# Altium schematic sheet pca70182.SchDoc
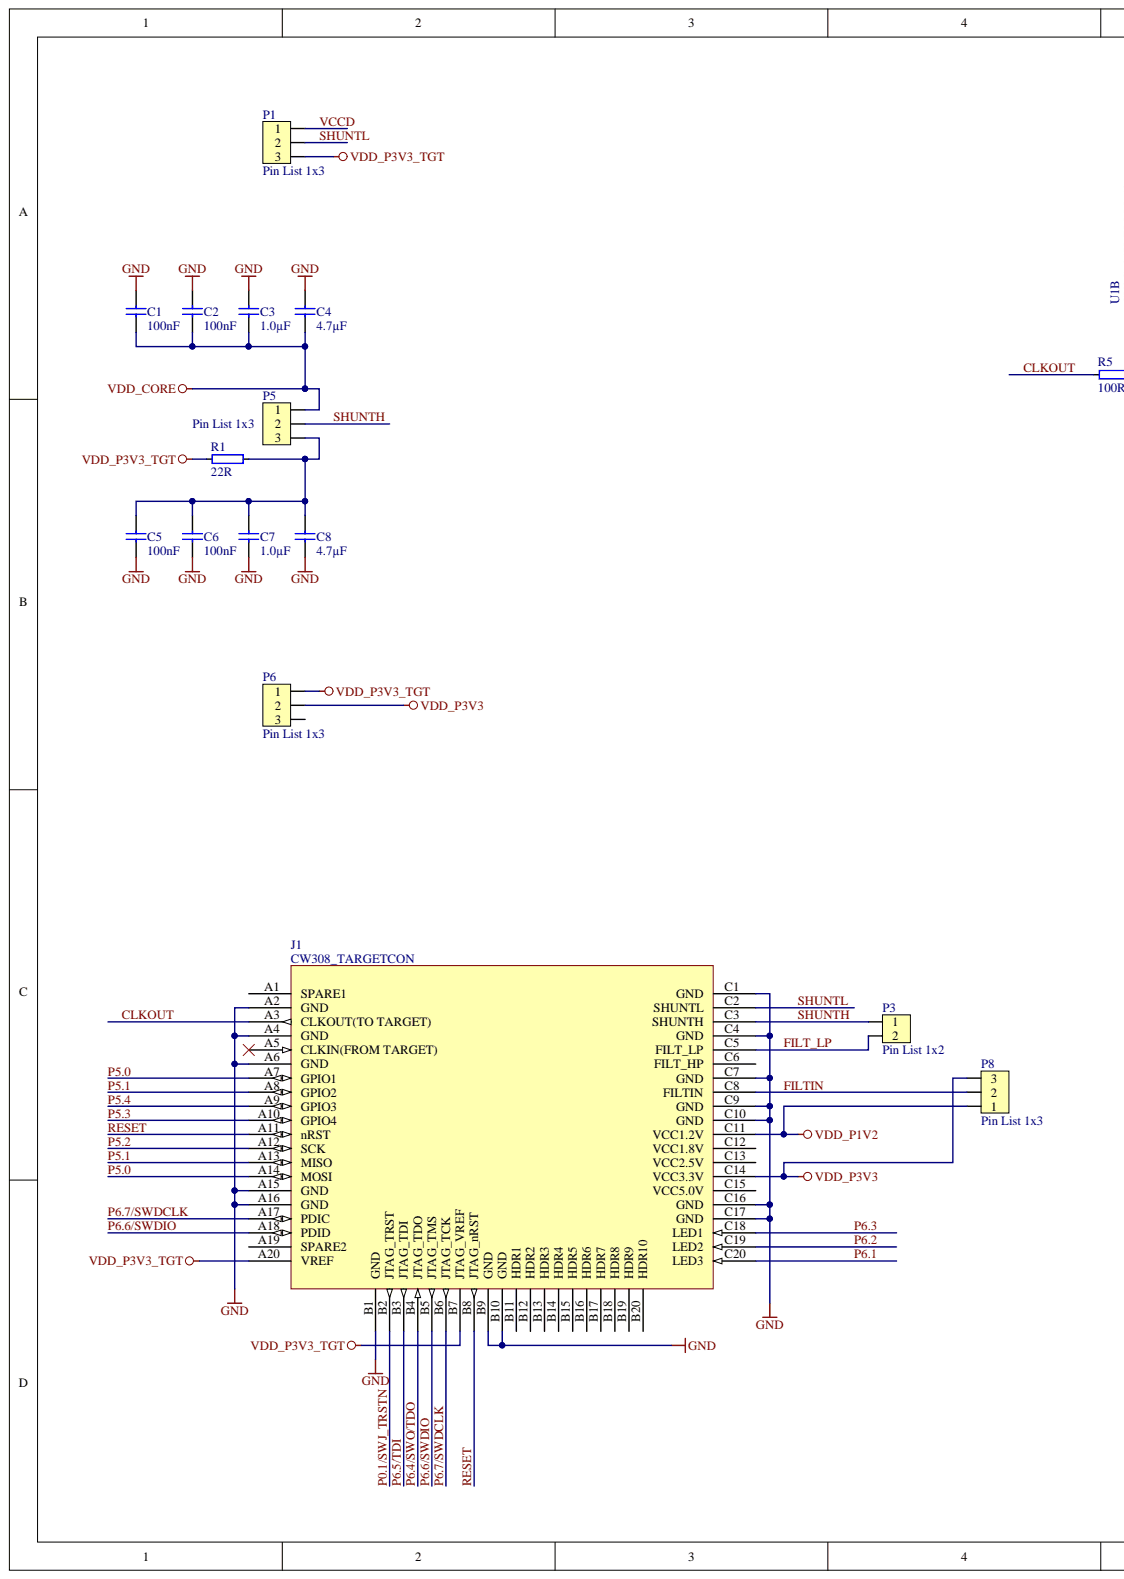
[diagram p0: pca70182.SchDoc, left]
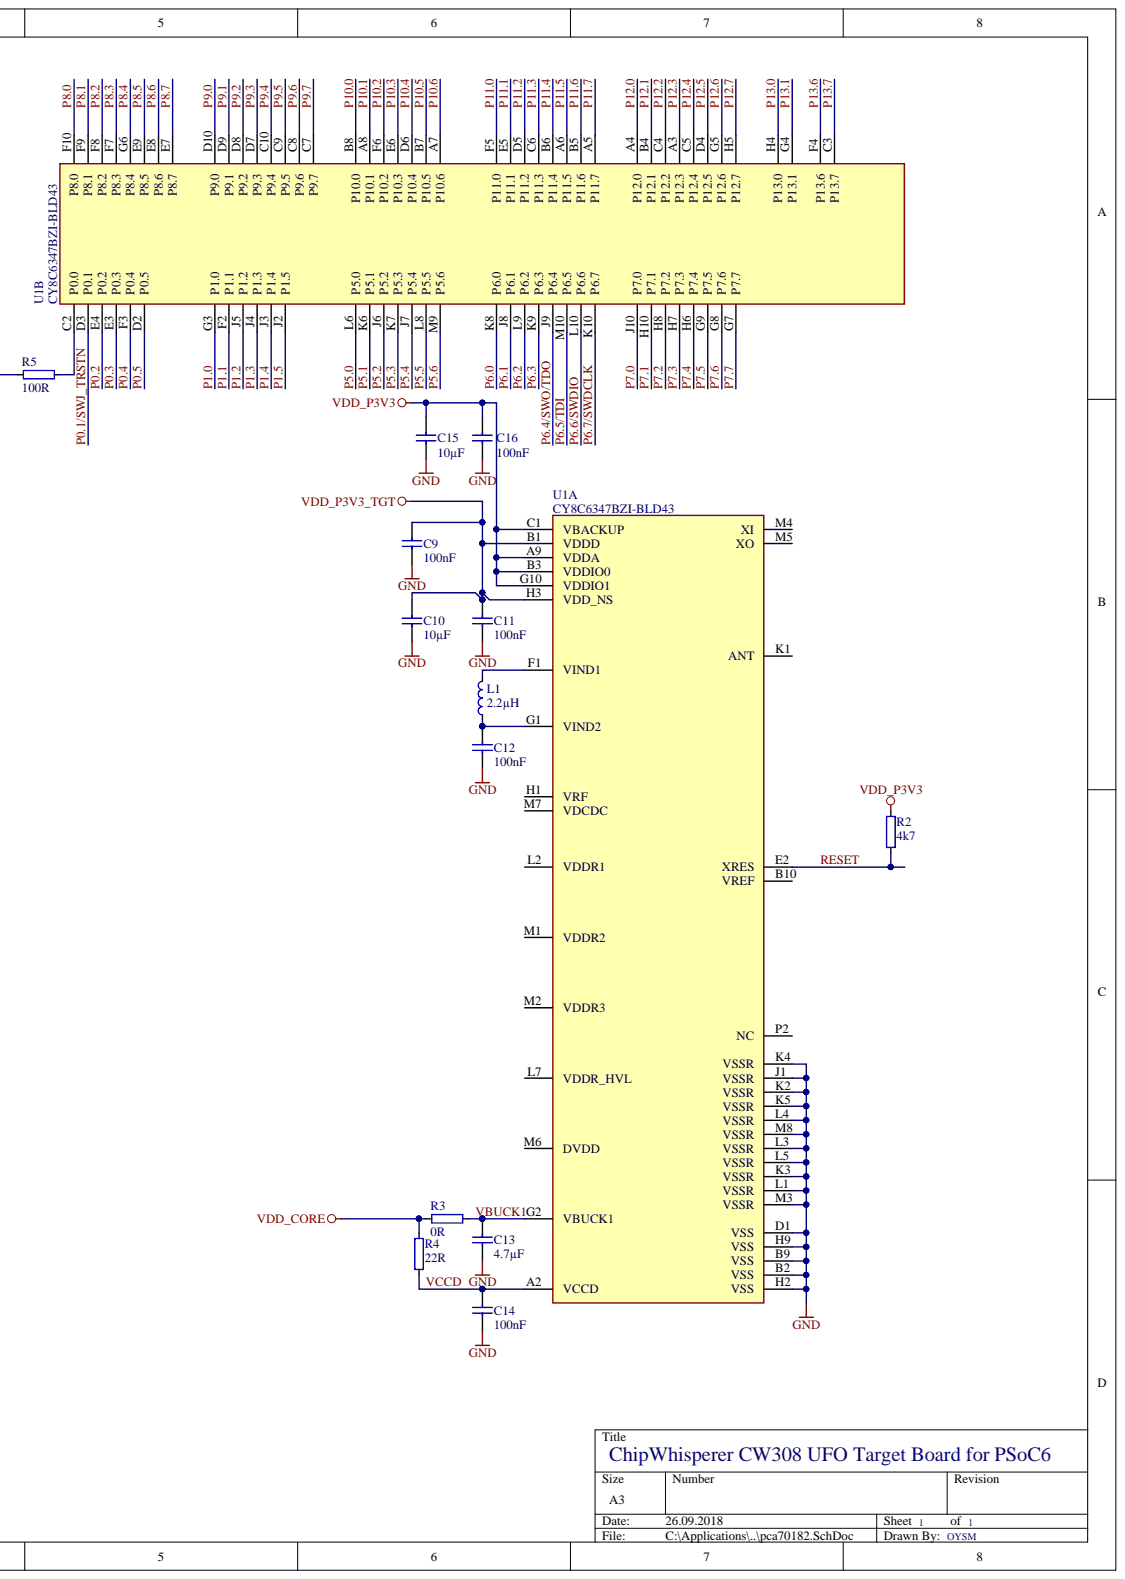
[diagram p1: pca70182.SchDoc, right]

## Parts
C1  100nF  [CAPC1608X06L]
C2  100nF  [CAPC1608X06L]
C3  1.0µF  [CAPC1608X06L]
C4  4.7µF  [CAPC1608X06L]
C5  100nF  [CAPC1608X06L]
C6  100nF  [CAPC1608X06L]
C7  1.0µF  [CAPC1608X06L]
C8  4.7µF  [CAPC1608X06L]
C9  100nF  [CAPC1608X06L]
C10  10µF  [CAPC1608X06L]
C11  100nF  [CAPC1608X06L]
C12  100nF  [CAPC1608X06L]
C13  4.7µF  [CAPC1608X06L]
C14  100nF  [CAPC1608X06L]
C15  10µF  [CAPC1608X06L]
C16  100nF  [CAPC1608X06L]
J1  CW308_TARGETCON  [CW308_TBOARD_CONN]
L1  2.2µH  [INDC1608X06L]
P1  Pin List 1x3  [LIST_1x3]
P3  Pin List 1x2  [LIST_1x2]
P5  Pin List 1x3  [LIST_1x3]
P6  Pin List 1x3  [LIST_1x3]
P8  Pin List 1x3  [LIST_1x3]
R1  22R  [RESC1608X06L]
R2  4k7  [RESC1608X06L]
R3  0R  [RESC1608X06L]
R4  22R  [RESC1608X06L]
R5  100R  [RESC1608X06L]
U1  CY8C6347BZI-BLD43  [BGA116C50P10X12_520X640X70]  (parts A,B)

## Nets
GND: C1.1 C2.1 C3.1 C4.1 C5.1 C6.1 C7.1 C8.1 C9.1 C10.1 C11.1 C12.1 C13.1 C14.1 C15.1 C16.1 J1.A2(GND) J1.A4(GND) J1.A6(GND) J1.A15(GND) J1.A16(GND) J1.B1(GND) J1.B9(GND) J1.B10(GND) J1.C1(GND) J1.C4(GND) J1.C7(GND) J1.C9(GND) J1.C10(GND) J1.C16(GND) J1.C17(GND) U1.B2(VSS) U1.B9(VSS) U1.D1(VSS) U1.H2(VSS) U1.H9(VSS) U1.J1(VSSR) U1.K2(VSSR) U1.K3(VSSR) U1.K4(VSSR) U1.K5(VSSR) U1.L1(VSSR) U1.L3(VSSR) U1.L4(VSSR) U1.L5(VSSR) U1.M3(VSSR) U1.M8(VSSR)
VDD_CORE: C1.2 C2.2 C3.2 C4.2 P5.1 R3.1 R4.2
VDD_P1V2: J1.C11(VCC1.2V) P8.1
VDD_P3V3: C15.2 C16.2 J1.C14(VCC3.3V) P6.2 P8.3 R2.2 U1.A9(VDDA) U1.B3(VDDIO0) U1.C1(VBACKUP) U1.G10(VDDIO1)
VDD_P3V3_TGT: C9.2 C10.2 C11.2 J1.A20(VREF) J1.B7(JTAG_VREF) P1.3 P6.1 R1.1 U1.B1(VDDD) U1.H3(VDD_NS)
CLKOUT: J1.A3(CLKOUT(TO_TARGET)) R5.1
FILT_LP: J1.C5(FILT_LP) P3.2
FILTIN: J1.C8(FILTIN) P8.2
P0.1/SWJ_TRSTN: J1.B2(JTAG_TRST) U1.D3(P0.1)
P0.2: U1.E4(P0.2)
P0.3: U1.E3(P0.3)
P0.4: U1.F3(P0.4)
P0.5: U1.D2(P0.5)
P1.0: U1.G3(P1.0)
P1.1: U1.F2(P1.1)
P1.2: U1.J5(P1.2)
P1.3: U1.J4(P1.3)
P1.4: U1.J3(P1.4)
P1.5: U1.J2(P1.5)
P5.0: J1.A7(GPIO1) J1.A14(MOSI) U1.L6(P5.0)
P5.1: J1.A8(GPIO2) J1.A13(MISO) U1.K6(P5.1)
P5.2: J1.A12(SCK) U1.J6(P5.2)
P5.3: J1.A10(GPIO4) U1.K7(P5.3)
P5.4: J1.A9(GPIO3) U1.J7(P5.4)
P5.5: U1.L8(P5.5)
P5.6: U1.M9(P5.6)
P6.0: U1.K8(P6.0)
P6.1: J1.C20(LED3) U1.J8(P6.1)
P6.2: J1.C19(LED2) U1.L9(P6.2)
P6.3: J1.C18(LED1) U1.K9(P6.3)
P6.4/SWO/TDO: J1.B4(JTAG_TDO) U1.J9(P6.4)
P6.5/TDI: J1.B3(JTAG_TDI) U1.M10(P6.5)
P6.6/SWDIO: J1.A18(PDID) J1.B5(JTAG_TMS) U1.L10(P6.6)
P6.7/SWDCLK: J1.A17(PDIC) J1.B6(JTAG_TCK) U1.K10(P6.7)
P7.0: U1.J10(P7.0)
P7.1: U1.H10(P7.1)
P7.2: U1.H8(P7.2)
P7.3: U1.H7(P7.3)
P7.4: U1.H6(P7.4)
P7.5: U1.G9(P7.5)
P7.6: U1.G8(P7.6)
P7.7: U1.G7(P7.7)
P8.0: U1.F10(P8.0)
P8.1: U1.F9(P8.1)
P8.2: U1.F8(P8.2)
P8.3: U1.F7(P8.3)
P8.4: U1.G6(P8.4)
P8.5: U1.E9(P8.5)
P8.6: U1.E8(P8.6)
P8.7: U1.E7(P8.7)
P9.0: U1.D10(P9.0)
P9.1: U1.D9(P9.1)
P9.2: U1.D8(P9.2)
P9.3: U1.D7(P9.3)
P9.4: U1.C10(P9.4)
P9.5: U1.C9(P9.5)
P9.6: U1.C8(P9.6)
P9.7: U1.C7(P9.7)
P10.0: U1.B8(P10.0)
P10.1: U1.A8(P10.1)
P10.2: U1.F6(P10.2)
P10.3: U1.E6(P10.3)
P10.4: U1.D6(P10.4)
P10.5: U1.B7(P10.5)
P10.6: U1.A7(P10.6)
P11.0: U1.F5(P11.0)
P11.1: U1.E5(P11.1)
P11.2: U1.D5(P11.2)
P11.3: U1.C6(P11.3)
P11.4: U1.B6(P11.4)
P11.5: U1.A6(P11.5)
P11.6: U1.B5(P11.6)
P11.7: U1.A5(P11.7)
P12.0: U1.A4(P12.0)
P12.1: U1.B4(P12.1)
P12.2: U1.C4(P12.2)
P12.3: U1.A3(P12.3)
P12.4: U1.C5(P12.4)
P12.5: U1.D4(P12.5)
P12.6: U1.G5(P12.6)
P12.7: U1.H5(P12.7)
P13.0: U1.H4(P13.0)
P13.1: U1.G4(P13.1)
P13.6: U1.F4(P13.6)
P13.7: U1.C3(P13.7)
RESET: J1.A11(nRST) J1.B8(JTAG_nRST) R2.1 U1.E2(XRES)
SHUNTH: J1.C3(SHUNTH) P3.1 P5.2
SHUNTL: J1.C2(SHUNTL) P1.2
VBUCK1: C13.2 R3.2 U1.G2(VBUCK1)
VCCD: C14.2 P1.1 R4.1 U1.A2(VCCD)
NetC5_2: C5.2 C6.2 C7.2 C8.2 P5.3 R1.2
NetC12_2: C12.2 L1.1 U1.G1(VIND2)
NetL1_2: L1.2 U1.F1(VIND1)
NetR5_2: R5.2 U1.C2(P0.0)

Not connected: J1 A1(SPARE1) A5(CLKIN(FROM_TARGET)) A19(SPARE2) B11(HDR1) B12(HDR2) B13(HDR3) B14(HDR4) B15(HDR5) B16(HDR6) B17(HDR7) B18(HDR8) B19(HDR9) B20(HDR10) C6(FILT_HP) C12(VCC1.8V) C13(VCC2.5V) C15(VCC5.0V); P6 3; U1 B10(VREF) H1(VRF) K1(ANT) L2(VDDR1) L7(VDDR_HVL) M1(VDDR2) M2(VDDR3) M4(XI) M5(XO) M6(DVDD) M7(VDCDC) P2(NC)

## Library data of the parts
C1 C2 C5 C6 C9 C11 C12 C14 C16 = C0603-100n: Chip Cap 0603; Artikkelnr Noca: N.A.; Database Table Name: Capacitors$; Note: Chip Cap 1%; Artikkelnr Simpro: N.A.; Component Kind: Standard; Footprint Doc: 0603; LatestRevisionDate: 2009-05-08; Library Name: Nordic Passive DB.DbLib; Part Number: C0603-100n; Pin Count: 2; Published: 2009-05-08; Value: 100nF; Publisher: Nordic Semiconductor
  C9 C11 C12 C14 C16: ComponentLink1Description: Datasheet; ComponentLink2Description: Supplier link
C3 C7 = C0603-1µ0: Capacitor, X7R, ±10%; Artikkelnr Noca: 20039335; Artikkelnr Simpro: N.A.; Database Table Name: Capacitors$; Note: 16V; Component Kind: Standard; Footprint Doc: 0603; LatestRevisionDate: 2013-06-05; Library Name: Nordic Passive DB.DbLib; Part Number: C0603-1µ0; Pin Count: 2; Published: 2009-05-08; Value: 1.0µF; Publisher: Nordic Semiconductor
C4 C8 = C0603-4µ7: Capacitor, X5R, ±10%; Artikkelnr Noca: 20069060; Artikkelnr Simpro: N.A.; Database Table Name: Capacitors$; Note: 6.3V; Component Kind: Standard; Footprint Doc: 0603; LatestRevisionDate: 2009-11-23; Library Name: Nordic Passive DB.DbLib; Part Number: C0603-4µ7; Pin Count: 2; Published: 2009-05-08; Value: 4.7µF; Publisher: Nordic Semiconductor
C10 C15 = C0603-10µ: Capacitor, X5R, ±20%; Part number IPS: 00000007785; Artikkelnr Noca: 20039234; Artikkelnr Simpro: N.A.; Database Table Name: Capacitors$; Note: 6.3V; Component Kind: Standard; ComponentLink1Description: Datasheet; ComponentLink2Description: Supplier link; Footprint Doc: 0603; LatestRevisionDate: 2011-01-17; Library Name: Nordic Passive DB.DbLib; Part Number: C0603-10µ; Pin Count: 2; Published: 2011-01-17; Value: 10µF; Publisher: Nordic Semiconductor
C13 = C0603-4µ7: Capacitor, X5R, ±10%; Part number IPS: 00000007783; Artikkelnr Noca: 20069060; Artikkelnr Simpro: N.A.; Database Table Name: Capacitors$; Note: 6.3V; Component Kind: Standard; ComponentLink1Description: Datasheet; ComponentLink2Description: Supplier link; Footprint Doc: 0603; LatestRevisionDate: 2009-11-23; Library Name: Nordic Passive DB.DbLib; Part Number: C0603-4µ7; Pin Count: 2; Published: 2009-05-08; Value: 4.7µF; Publisher: Nordic Semiconductor
J1 = CW308_TBOARD: CW308 "Standard" Target Board Connector; Component Kind: Standard; Library Name: ChipWhisperer_AltiumLib.SchLib
L1 = L0603-2.2µH: FIXED IND 2.2UH 700MA 300 MOHM; Manufacturer: Murata; Manufacturer Part Number: LQM18PN2R2NC0; Artikkelnr Noca: N.A.; Database Table Name: Inductors$; Note: -55°C ~ 125°C; Artikkelnr Simpro: N.A.; Component Kind: Standard; ComponentLink1Description: Datasheet; ComponentLink2Description: Supplier link; Footprint Doc: 0603; HelpURL: http://www.murata.com/~/media/webrenewal/support/library/catalog/products/inductor/chip/o05e.ashx; LatestRevisionDate: 2016-04-19; Library Name: Nordic Passive DB.DbLib; Part Number: L0603-2.2µH; Pin Count: 2; Published: 2016-04-19; Value: 2.2µH; Publisher: Nordic Semiconductor
P1 P5 P6 P8 = Pin List 1x3: Pin List 1x3, 2.54mm (100mil); Artikkelnr Noca: 56019041; Artikkelnr Simpro: N.A.; Database Table Name: Connectors$; Component Kind: Standard; Footprint Doc: LIST_1x3; LatestRevisionDate: 2009-12-12; Library Name: Nordic Passive DB.DbLib; Part Number: Pin List 1x3; Pin Count: 3; Published: 2009-12-12; Value: Pin List 1x3; Publisher: Nordic Semiconductor
P3 = Pin List 1x2: Pin List 1x2, 2.54mm (100mil); Artikkelnr Noca: 56019040; Artikkelnr Simpro: N.A.; Database Table Name: Connectors$; Component Kind: Standard; Footprint Doc: LIST_1x2; LatestRevisionDate: 2009-12-12; Library Name: Nordic Passive DB.DbLib; Part Number: Pin List 1x2; Pin Count: 2; Published: 2009-12-12; Value: Pin List 1x2; Publisher: Nordic Semiconductor
R1 R4 = R0603-22R: Resistor, ±1%, 0.1W; Artikkelnr Noca: 27069033; Artikkelnr Simpro: N.A.; Database Table Name: Resistors$; Note: Chip Res 1%; Value Ohm: 22; Component Kind: Standard; Footprint Doc: 0603; LatestRevisionDate: 2011-08-16; Library Name: Nordic Passive DB.DbLib; Part Number: R0603-22R; Pin Count: 2; Published: 2009-05-08; Value: 22R; Publisher: Nordic Semiconductor
R2 = R0603-4k7: Resistor, ±1%, 0.06W; Artikkelnr Noca: 27069089; Artikkelnr Simpro: N.A.; Database Table Name: Resistors$; Note: Chip Res 1%; Value Ohm: 43285000; Component Kind: Standard; ComponentLink1Description: Datasheet; ComponentLink2Description: Supplier link; Footprint Doc: 0603; LatestRevisionDate: 2011-08-16; Library Name: Nordic Passive DB.DbLib; Part Number: R0603-4k7; Pin Count: 2; Published: 2009-05-08; Value: 4k7; Publisher: Nordic Semiconductor
R3 = R0603-0R: Resistor, ±5%, 0.1W; Artikkelnr Noca: 27069000; Artikkelnr Simpro: N.A.; Database Table Name: Resistors$; Note: Chip Res 5%; Value Ohm: #VALUE!; Component Kind: Standard; ComponentLink1Description: Datasheet; ComponentLink2Description: Supplier link; Footprint Doc: 0603; LatestRevisionDate: 2011-12-14; Library Name: Nordic Passive DB.DbLib; Part Number: R0603-0R; Pin Count: 2; Published: 2009-05-08; Value: 0R; Publisher: Nordic Semiconductor
R5 = R0603-100: Resistor, ±1%, 0.1W; Artikkelnr Noca: 27069049; Artikkelnr Simpro: N.A.; Database Table Name: Resistors$; Note: Chip Res 1%; Value Ohm: #VALUE!; Component Kind: Standard; ComponentLink1Description: Datasheet; ComponentLink2Description: Supplier link; Footprint Doc: 0603; LatestRevisionDate: 2011-08-16; Library Name: Nordic Passive DB.DbLib; Part Number: R0603-100; Pin Count: 2; Published: 2009-05-08; Value: 100R; Publisher: Nordic Semiconductor
U1 = CY8C6347BZI-BLD43: Cypress PSoC 6 soc with BLE and cryptographic accelerators; Manufacturer: Cypress Semiconductor; Artikkelnr Noca: N.A.; Database Table Name: Misc IC$; Note: PSoC6; Artikkelnr Simpro: N.A.; Component Kind: Standard; ComponentLink1Description: Datasheet; ComponentLink1URL: http://www.cypress.com/file/385921/download; ComponentLink2Description: Supplier link; Footprint Doc: BGA-116; HelpURL: http://www.cypress.com/part/cy8c6347bzi-bld43; LatestRevisionDate: 2018-04-10; Library Name: Nordic Active DB.DbLib; Manufacturer Part Number: CY8C6347BZI-BLD43; Part Number: CY8C6347BZI-BLD43; Part number IPS: N.A.; Pin Count: 116; Published: 2018-04-10; Value: CY8C6347BZI-BLD43; Publisher: Nordic Semiconductor
